# Altium schematic sheet ToF.SchDoc
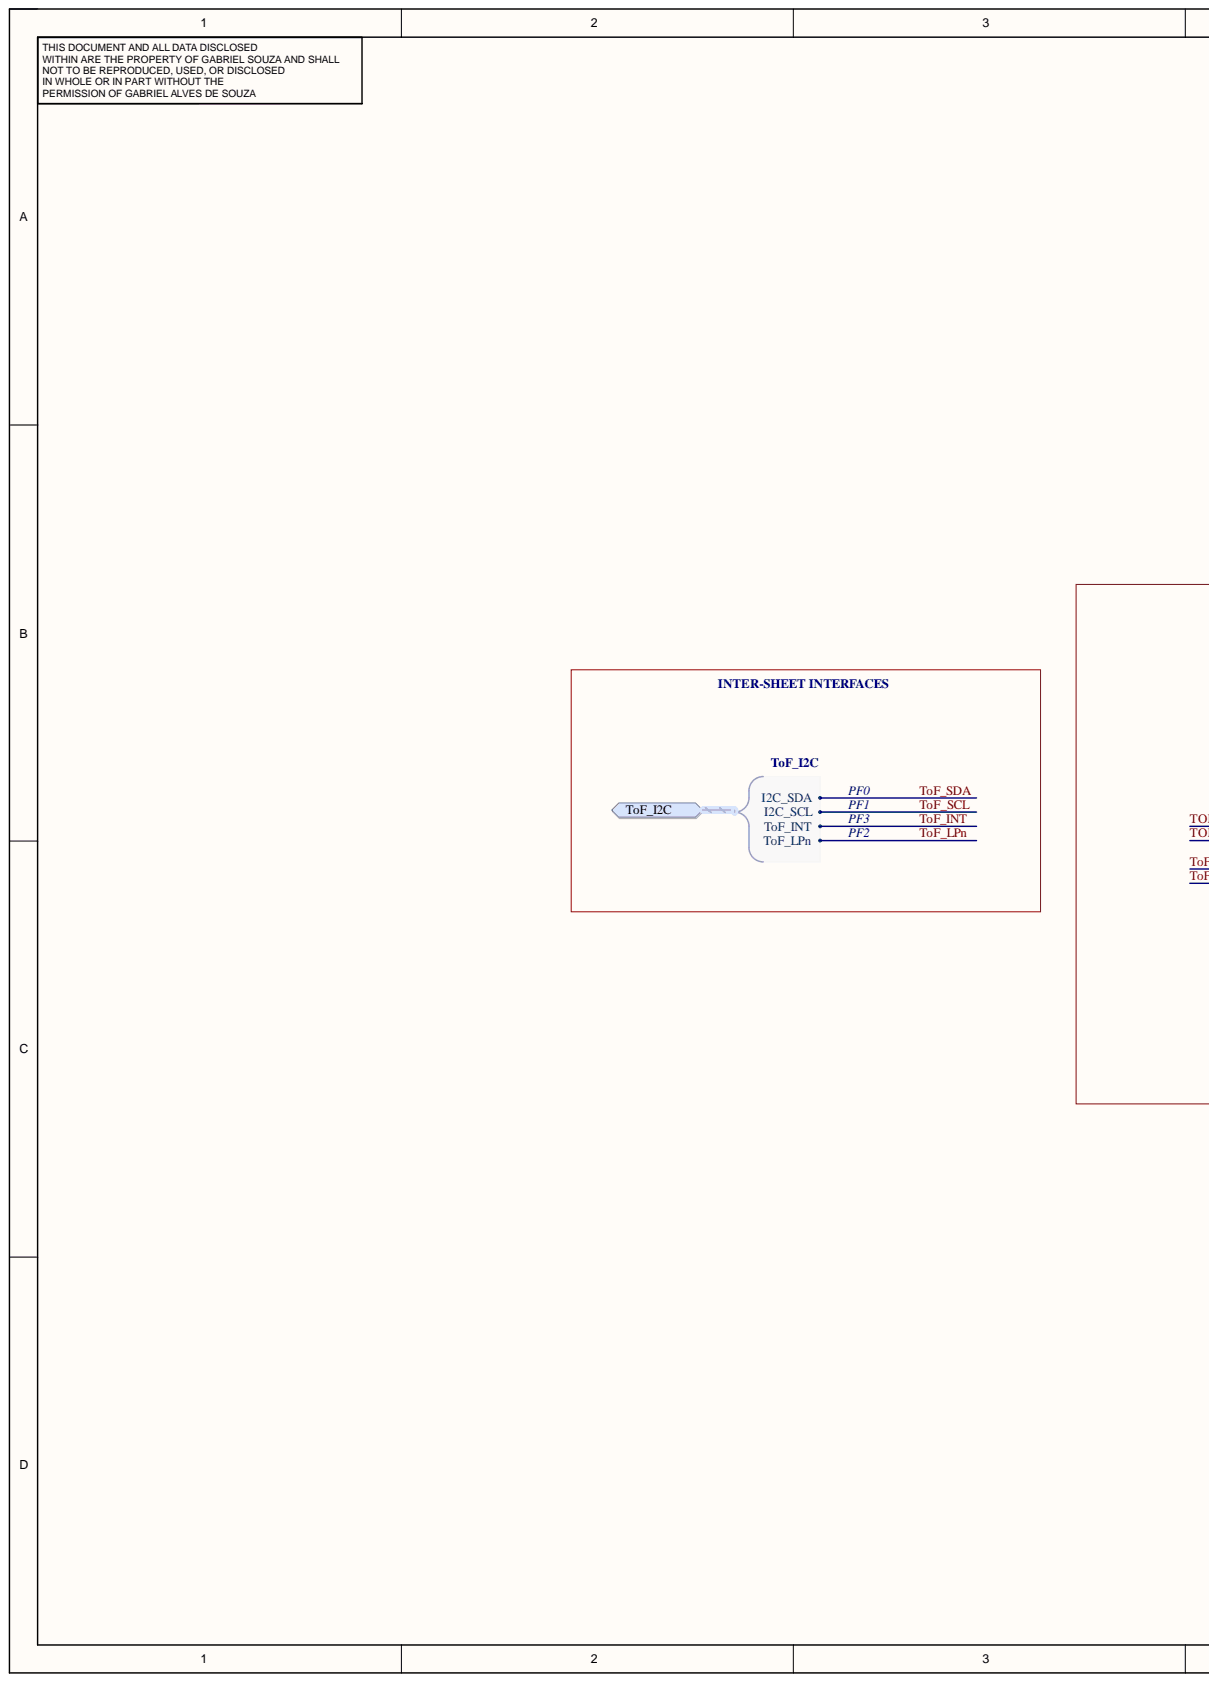
[diagram p0: ToF.SchDoc, left]
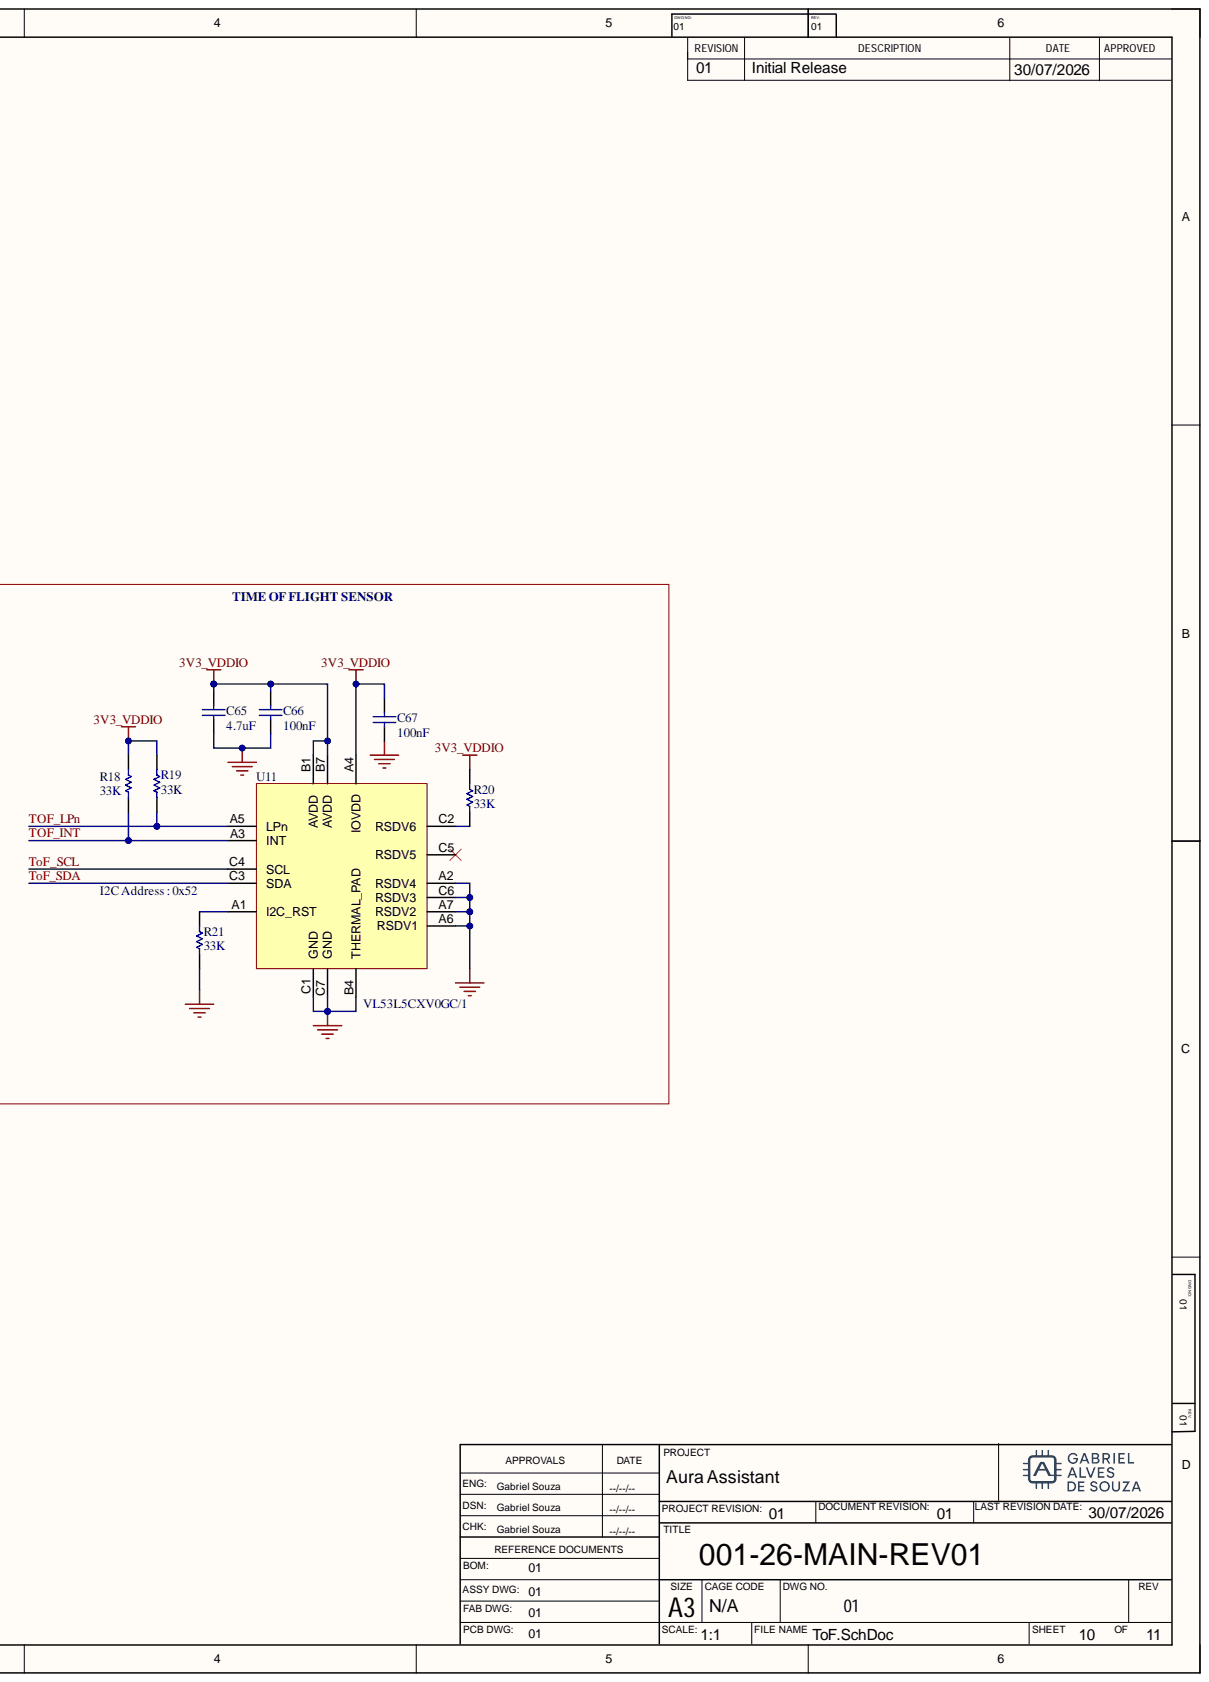
[diagram p1: ToF.SchDoc, right]

## Parts
C65  value 4.7uF  [C_0603_IPC_N]  (hidden comment: Capacitor)
C66  value 100nF  [C_0402_IPC_N]  (hidden comment: Capacitor)
C67  value 100nF  [C_0402_IPC_N]  (hidden comment: Capacitor)
R18  value 33K  [R_0402_IPC_N]  (hidden comment: Resistor)
R19  value 33K  [R_0402_IPC_N]  (hidden comment: Resistor)
R20  value 33K  [R_0402_IPC_N]  (hidden comment: Resistor)
R21  value 33K  [R_0402_IPC_N]  (hidden comment: Resistor)
U11  VL53L5CXV0GC/1  [ICC_LGA16_6P4X3_P075_H1P5]

## Ports
ToF_I2C, ToF_I2C.I2C_SCL, ToF_I2C.I2C_SDA, ToF_I2C.ToF_INT, ToF_I2C.ToF_LPn

## Nets
3V3_VDDIO: C65.2 C66.2 C67.2 R18.1 R19.1 R20.1 U11.A4(IOVDD) U11.B1(AVDD) U11.B7(AVDD)
GND: C65.1 C66.1 C67.1 R21.2 U11.A2(RSDV4) U11.A6(RSDV1) U11.A7(RSDV2) U11.B4(THERMAL_PAD) U11.C1(GND) U11.C6(RSDV3) U11.C7(GND)
ToF_INT: R18.2 U11.A3(INT) ; port ToF_I2C
ToF_LPn: R19.2 U11.A5(LPn) ; port ToF_I2C
ToF_SCL: U11.C4(SCL) ; port ToF_I2C
ToF_SDA: U11.C3(SDA) ; port ToF_I2C
NetR20_2: R20.2 U11.C2(RSDV6)
NetR21_1: R21.1 U11.A1(I2C_RST)

Not connected: U11 C5(RSDV5)

## Library data of the parts
C65 = CAP: Capacitor, MLCC, 4.7uF, 10V, X7R, +/-20%, 0603, T=0,5mm; Manufacturer: muRata; Manufacturer_Part_Number: GRM188Z71A475ME15D; Component Kind: Standard; ComponentLink1Description: Datasheet; ComponentLink1URL: https://www.lcsc.com/datasheet/C338032.pdf?spm=wm.sxq.inf.ggs___wm.fly.bg.6.xh&lcsc_vid=TlIKVlcFRVJWVl1WQVFfBlQDRFgPAVVQRFhaBQIFFgQxVlNeRVRbU1RQQ1dWUTtW; Order_Code_LCSC: C3835885; Package: 0603; Library Name: Gabriel_Lib.IntLib
C66 C67 = CAP: Capacitor, MLCC, 100nF, 16V, X7R, +/-10%, 0402, T=0,5mm; Manufacturer: TDK; Manufacturer_Part_Number: C1005X7R1C104KT000F; Component Kind: Standard; ComponentLink1Description: Datasheet; ComponentLink1URL: https://www.lcsc.com/datasheet/C338032.pdf?spm=wm.sxq.inf.ggs___wm.fly.bg.6.xh&lcsc_vid=TlIKVlcFRVJWVl1WQVFfBlQDRFgPAVVQRFhaBQIFFgQxVlNeRVRbU1RQQ1dWUTtW; Order_Code_LCSC: C338032; Package: 0402; Library Name: Gabriel_Lib.IntLib
R18-R21 = RES: Resistor, 33K, 0402, 0.1%, 62.5mW; Manufacturer: YAGEO; Manufacturer_Part_Number: RT0402BRD0733KL; Component Kind: Standard; ComponentLink1Description: Datasheet; Order_Code_LCSC: C728559; Package: 0402; Part_Type: Resistor; Library Name: Gabriel_Lib.IntLib
U11 = MCD-02590: Sensor, Time-of-Flight 8x8 multizone ranging, with wide field of view; Manufacturer: STMICROELECTRONICS; Part_Preference: Recommended; Part_Type: IC; Component_Country_Of_Origin: China; Database Table Name: MCD_PARTS$; ID: 4696; ShowFields: YES; Component Kind: Standard; ComponentLink1URL: http://tunmcdsvn.tun.st.com/Component_Documentation_Library/Trunk/IC/STMICROELECTRONICS_VL53L5CXV0GC-1; Internal_Part_Number: MCD-02590; Library Name: MCD_PARTS_database.DbLib; Manufacturer_Part_Number: VL53L5CXV0GC/1; Order_Code_Digikey: N/A; Order_Code_Farnell: N/A; Order_Code_LCSC: C3178303; Package: Optical LGA-16; Status: Released; Value: VL53L5CXV0GC/1
